# Altium schematic sheet MCU.SchDoc
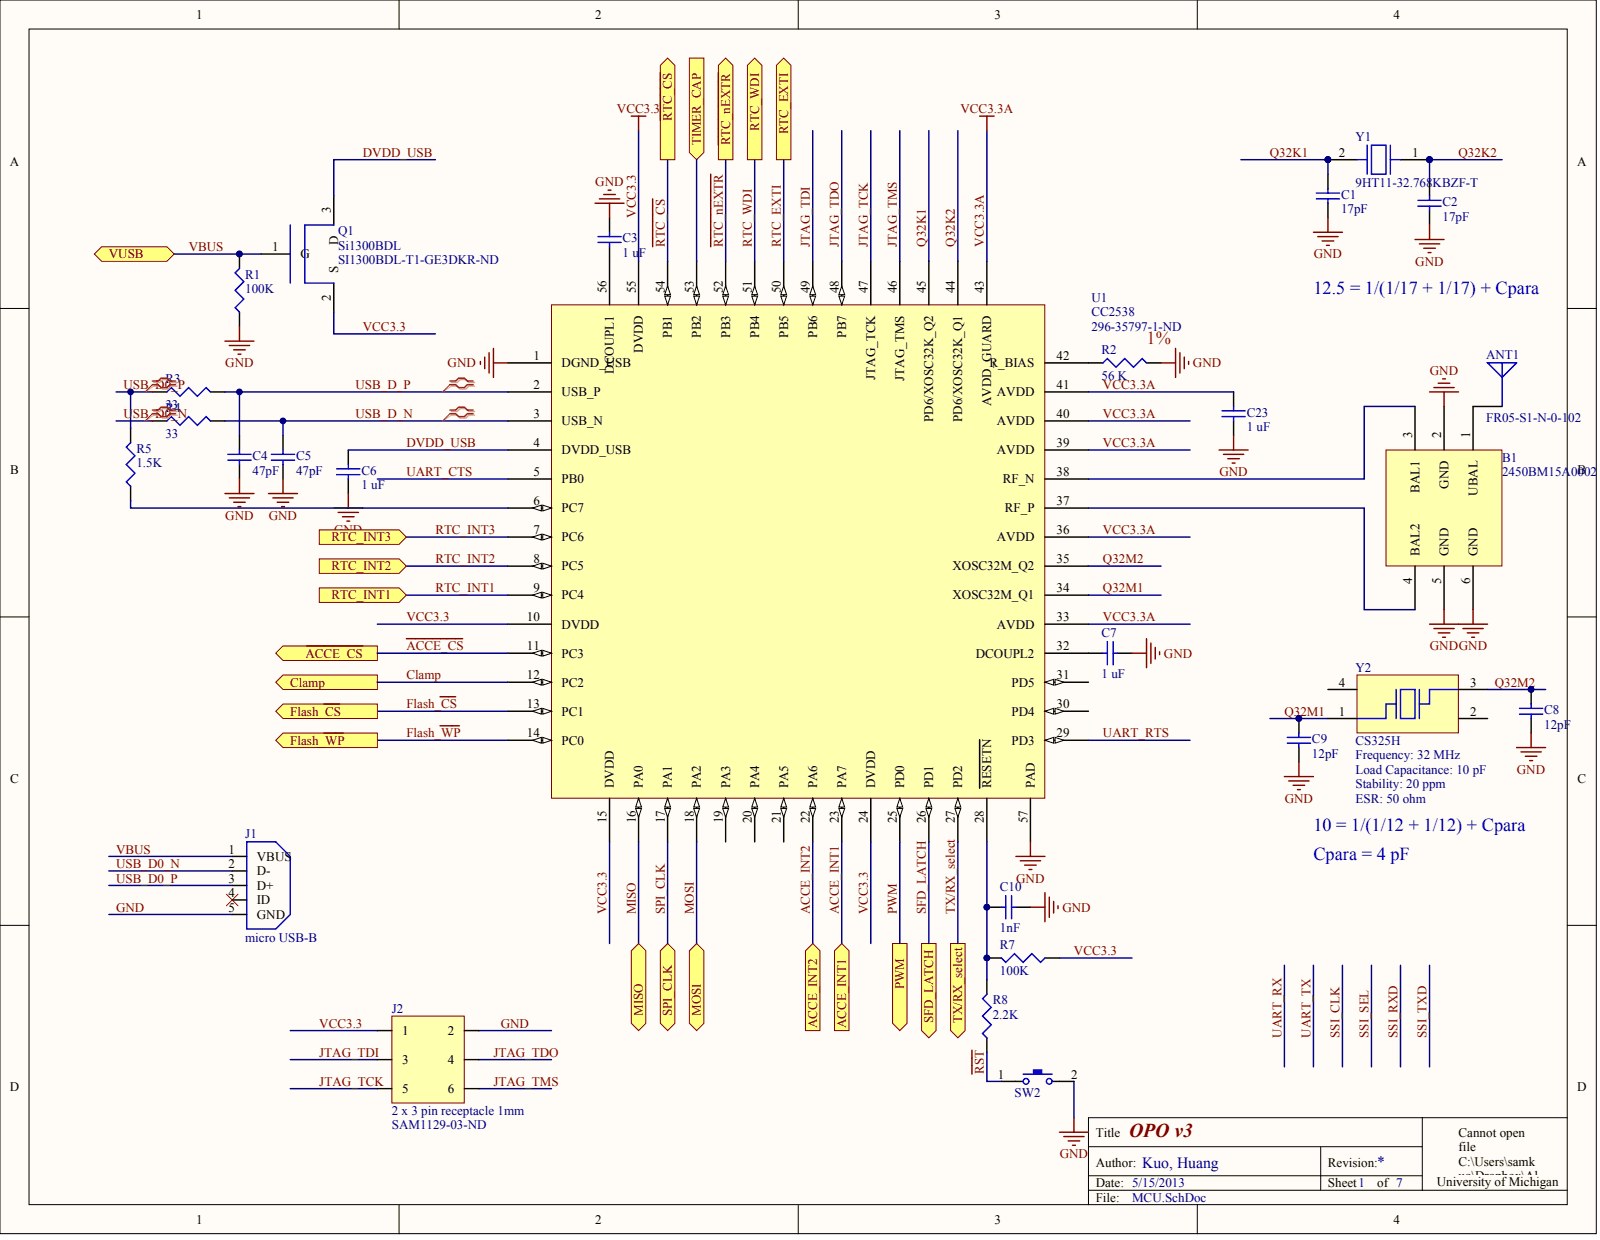
[diagram p0: MCU.SchDoc]

## Parts
ANT1  FR05-S1-N-0-102
B1  2450BM15A0002
C1  value 17pF  (hidden comment: Cap Semi)
C2  value 17pF  (hidden comment: Cap Semi)
C3  value 1 uF  (hidden comment: Cap Semi)
C4  value 47pF  (hidden comment: Cap Semi)
C5  value 47pF  (hidden comment: Cap Semi)
C6  value 1 uF  (hidden comment: Cap Semi)
C7  value 1 uF  (hidden comment: Cap Semi)
C8  value 12pF  (hidden comment: Cap Semi)
C9  value 12pF  (hidden comment: Cap Semi)
C10  value 1nF  (hidden comment: Cap Semi)
C23  value 1 uF  (hidden comment: Cap Semi)
J1  micro USB-B
J2  2 x 3 pin receptacle 1mm  Supplier Part Number 1: SAM1129-03-ND
Q1  Si1300BDL  Supplier Part Number 1: SI1300BDL-T1-GE3DKR-ND
R1  value 100K  (hidden comment: Res3)
R2  value 56 K  (hidden comment: Res3)
R3  value 33  (hidden comment: Res3)
R4  value 33  (hidden comment: Res3)
R5  value 1.5K  (hidden comment: Res3)
R7  value 100K  (hidden comment: Res3)
R8  value 2.2K  (hidden comment: Res3)
SW2  Tactile Switch  (value not drawn)
U1  CC2538  Supplier Part Number 1: 296-35797-1-ND
Y1  9HT11-32.768KBZF-T
Y2  CS325H  Frequency: 32 MHz

## Ports
ACCE_INT1, ACCE_INT2, CLAMP, FLASH_~{CS}, FLASH_~{WP}, MISO, MOSI, PWM, RTC_EXTI, RTC_INT1, RTC_INT2, RTC_INT3, RTC_WDI, SFD_LATCH, SPI_CLK, TIMER_CAP, TX/RX_SELECT, VUSB, ~{ACCE_CS}, ~{RTC_CS}, ~{RTC_NEXTR}

## Nets
~{ACCE_CS}: U1.11(PC3) ; port ~{ACCE_CS}
ACCE_INT1: U1.23(PA7) ; port ACCE_INT1
ACCE_INT2: U1.22(PA6) ; port ACCE_INT2
CLAMP: U1.12(PC2) ; port CLAMP
DVDD_USB: C6.1 Q1.3 U1.4(DVDD_USB)
FLASH_~{CS}: U1.13(PC1) ; port FLASH_~{CS}
FLASH_~{WP}: U1.14(PC0) ; port FLASH_~{WP}
GND: B1.2(GND) B1.5(GND) B1.6(GND) C1.1 C2.1 C3.2 C4.2 C5.2 C6.2 C7.2 C8.1 C9.1 C10.1 C23.2 J1.5(GND) J2.2 R1.1 R2.2 SW2.2 U1.1(DGND_USB) U1.57(PAD)
JTAG_TCK: J2.5 U1.47(JTAG_TCK)
JTAG_TDI: J2.3 U1.49(PB6)
JTAG_TDO: J2.4 U1.48(PB7)
JTAG_TMS: J2.6 U1.46(JTAG_TMS)
MISO: U1.16(PA0) ; port MISO
MOSI: U1.18(PA2) ; port MOSI
PWM: U1.25(PD0) ; port PWM
Q32K1: C1.2 U1.45(PD6/XOSC32K_Q2) Y1.2
Q32K2: C2.2 U1.44(PD6/XOSC32K_Q1) Y1.1
Q32M1: C9.2 U1.34(XOSC32M_Q1) Y2.1
Q32M2: C8.2 U1.35(XOSC32M_Q2) Y2.3
~{RST}: R8.1 SW2.1
~{RTC_CS}: U1.54(PB1) ; port ~{RTC_CS}
~{RTC_NEXTR}: U1.52(PB3) ; port ~{RTC_NEXTR}
RTC_EXTI: U1.50(PB5) ; port RTC_EXTI
RTC_INT1: U1.9(PC4) ; port RTC_INT1
RTC_INT2: U1.8(PC5) ; port RTC_INT2
RTC_INT3: U1.7(PC6) ; port RTC_INT3
RTC_WDI: U1.51(PB4) ; port RTC_WDI
SFD_LATCH: U1.26(PD1) ; port SFD_LATCH
SPI_CLK: U1.17(PA1) ; port SPI_CLK
TIMER_CAP: U1.53(PB2) ; port TIMER_CAP
TX/RX_SELECT: U1.27(PD2) ; port TX/RX_SELECT
UART_CTS: U1.5(PB0)
UART_RTS: U1.29(PD3)
USB_D0_N: J1.2(D-) R4.1
USB_D0_P: J1.3(D+) R3.1 R5.2
USB_D_N: C5.1 R4.2 U1.3(USB_N)
USB_D_P: C4.1 R3.2 U1.2(USB_P)
VBUS: J1.1(VBUS) Q1.1 R1.2 ; port VUSB
VCC3.3: J2.1 Q1.2 R7.1 U1.10(DVDD) U1.15(DVDD) U1.24(DVDD) U1.55(DVDD)
VCC3.3A: C23.1 U1.33(AVDD) U1.36(AVDD) U1.39(AVDD) U1.40(AVDD) U1.41(AVDD) U1.43(AVDD_GUARD)
NetANT1_IN: ANT1.IN B1.1(UBAL)
NetB1_3: B1.3(BAL1) U1.38(RF_N)
NetB1_4: B1.4(BAL2) U1.37(RF_P)
NetC3_1: C3.1 U1.56(DCOUPL1)
NetC7_1: C7.1 U1.32(DCOUPL2)
NetC10_2: C10.2 R7.2 R8.2 U1.28(RESETN)
NetR2_1: R2.1 U1.42(R_BIAS)
NetR5_1: R5.1 U1.6(PC7)
SSI_CLK:
SSI_RXD:
SSI_SEL:
SSI_TXD:
UART_RX:
UART_TX:

Not connected: J1 4(ID); U1 19(PA3) 20(PA4) 21(PA5) 30(PD4) 31(PD5); Y2 2 4

## Library data of the parts
C3 = (no symbol name): Value: 1 uF; Published: 8-Jun-2000; LatestRevisionDate: 29-May-2009; LatestRevisionNote: IPC-7351 Footprint Added.; PackageReference: C1206; PackageDescription: Chip Capacitor; Publisher: Altium Limited
Y2 = (no symbol name): Shunt Capacitance: 5 pF max; Load Capacitance: 10 pF; Stability: 20 ppm; ESR: 50 ohm; Supplier 1: Digi-Key; Supplier Part Number 1: 300-8757-1-ND
ANT1 B1 C1 C2 C4-C10 C23 J1 J2 Q1 R1-R5 R7 R8 SW2 U1 Y1 = (no symbol name)
  B1: Supplier 1: Digi-Key; Supplier Part Number 1: 712-1536-2-ND
  C1 C2 C4-C10 C23: Published: 8-Jun-2000; LatestRevisionDate: 29-May-2009; LatestRevisionNote: IPC-7351 Footprint Added.; PackageReference: C1206; PackageDescription: Chip Capacitor
  J1: Supplier 1: Digi-Key; Supplier Part Number 1: 609-4616-6-ND
  J2 Q1 U1: Supplier 1: Digi-Key
  R1-R5 R7 R8: Published: 8-Jun-2000; LatestRevisionDate: 29-May-2009; LatestRevisionNote: IPC-7351 Footprint Added.; PackageReference: J1-0603; PackageDescription: Chip Resistor
  SW2: Supplier 1: Digi-Key; Supplier Part Number 1: P12298SCT-ND; Supplier 2: Digi-Key; Supplier Part Number 2: SW1021DKR-ND
  Y1: Supplier 1: Digi-Key; Supplier Part Number 1: 887-1574-1-ND
  C1 C2 C4-C10 C23 R1-R5 R7 R8: Publisher: Altium Limited
